# Altium schematic sheet Sheet1.SchDoc
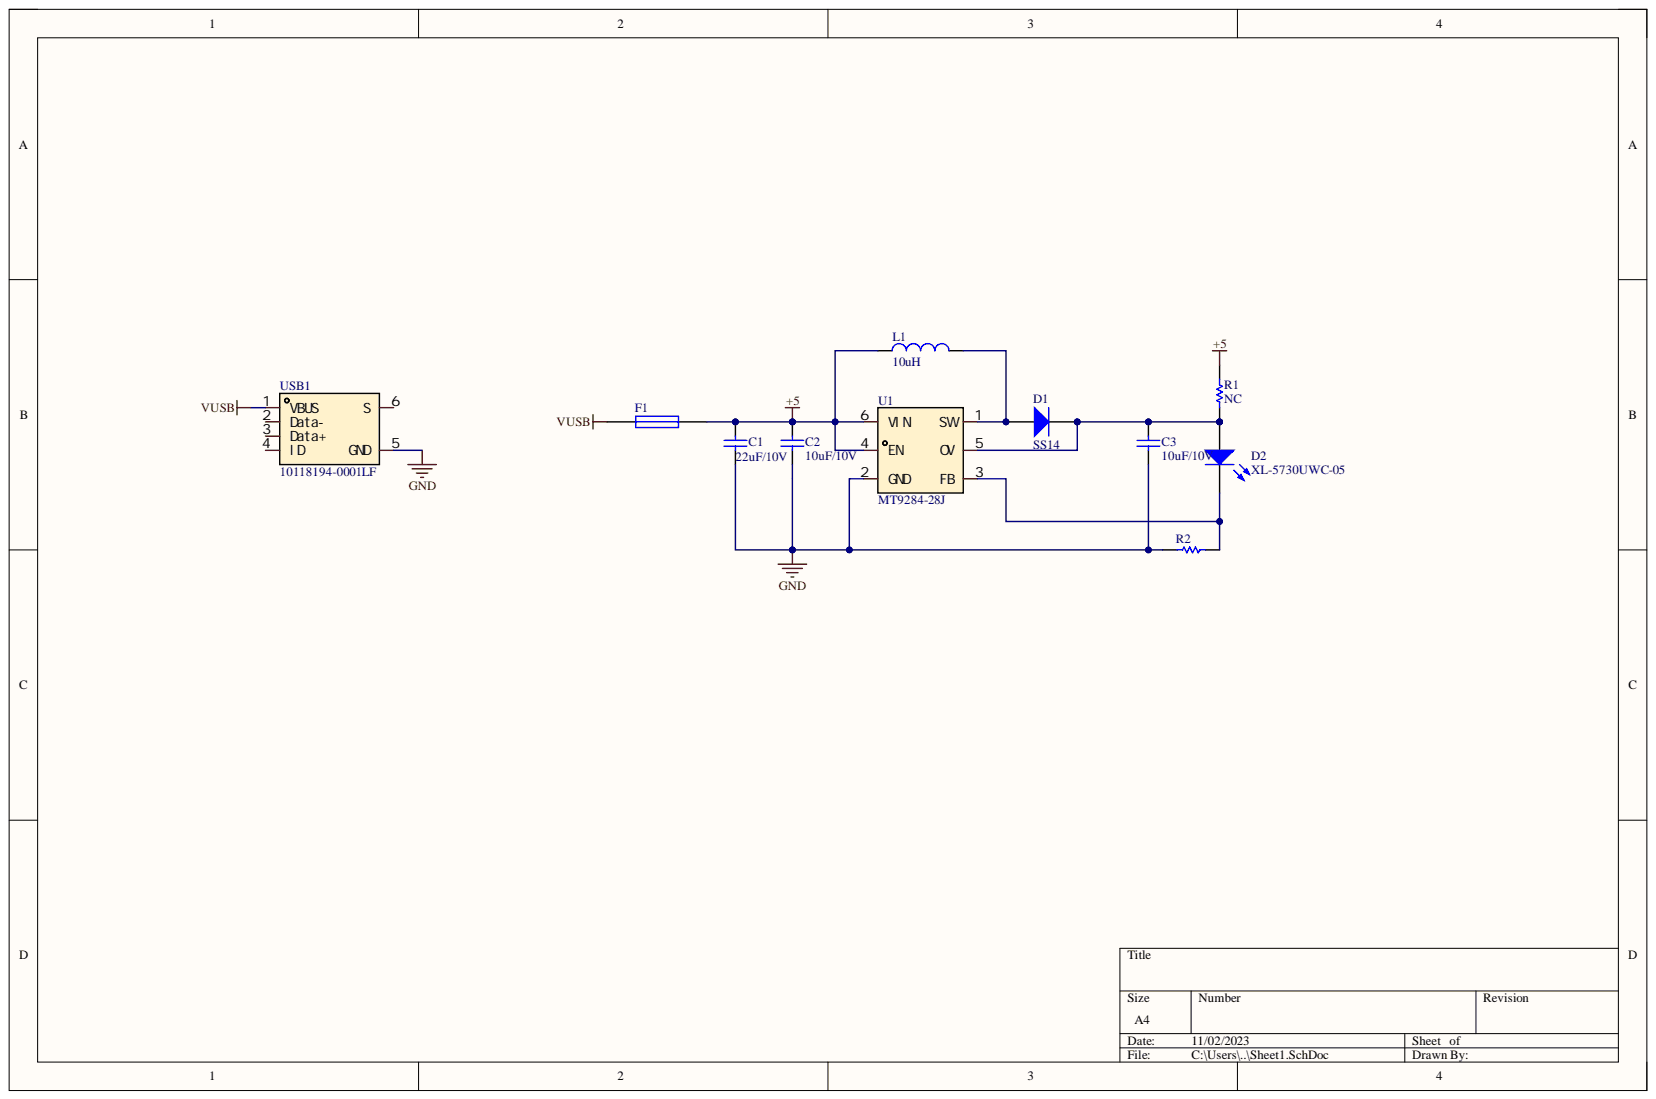
[diagram p0: Sheet1.SchDoc]

## Parts
C1  22uF/10V  [0805-C]
C2  10uF/10V  [0805-C]
C3  10uF/10V  [0805-C]
D1  SS14  [SMA(DO-214AC)]
D2  XL-5730UWC-05  [LED-SMD_3P-L5.7-W3.0-RD]
F1  BSMD0805-050-24V  [0805-L]  (value not drawn)
L1  value 10uH  [IND-SMD_L4.0-W4.0]  (hidden comment: SWPA4030S100MT)
R1  NC  [0805-L]
R2  Res1  [0805-L]  (value not drawn)
U1  MT9284-28J  [SOT-23-6]
USB1  10118194-0001LF  [MICRO-USB-SMD]

## Nets
+5: C1.1 C2.2 F1.1 L1.1 R1.1 U1.4(EN) U1.6(VIN)
GND: C1.2 C2.1 C3.1 R2.1 U1.2(GND) USB1.5(GND)
VUSB: F1.2 USB1.1(VBUS)
NetC3_2: C3.2 D1.2 D2.1 R1.2 U1.5(OV)
NetD1_1: D1.1 L1.2 U1.1(SW)
NetD2_2: D2.2 R2.2 U1.3(FB)

Not connected: USB1 2(Data-) 3(Data+) 4(ID) 6(S)

## Library data of the parts
C1 = Cap: 贴片电容; Component Kind: Standard; LatestRevisionDate: 17-Jul-2002; LatestRevisionNote: Re-released for DXP Platform.; Library Name: Miscellaneous Devices.IntLib; PackageDescription: Radial Cap, Thru-Hole; 2 Leads; 0.3 in Pin Spacing; PackageReference: RAD-0.3; Published: 8-Jun-2000; Signal Integrity: Cap; Simulation: CAP; Publisher: Altium Limited
C2 C3 = Cap: Capacitor; Value: 100pF; Component Kind: Standard; LatestRevisionDate: 17-Jul-2002; LatestRevisionNote: Re-released for DXP Platform.; Library Name: Miscellaneous Devices.IntLib; PackageDescription: Radial Cap, Thru-Hole; 2 Leads; 0.3 in Pin Spacing; PackageReference: RAD-0.3; Published: 8-Jun-2000; Signal Integrity: Cap; Simulation: CAP; Publisher: Altium Limited
D1 = Diode: Default Diode; Code_JEDEC: DO-214-AB; Component Kind: Standard; LatestRevisionDate: 17-Jul-2002; LatestRevisionNote: Re-released for DXP Platform.; Library Name: Miscellaneous Devices.IntLib; PackageDescription: DO-214-AB/SMC; 2 C-Bend Leads; Body 7.9 x 5.9 mm, inc. leads (LxW); PackageReference: SMC; PackageVersion: Sep-1996; Published: 8-Jun-2000; Signal Integrity: Diode; Simulation: DIODE; Publisher: Altium Limited
D2 = LED1: LED-绿; Component Kind: Standard; LatestRevisionDate: 08-Jul-2005; LatestRevisionNote: Mech Layer 1 removed.; Library Name: Schlib1.SchLib; PackageDescription: LED; 2 Leads; PackageReference: LED-1; Published: 8-Jun-2000; Simulation: LED1; Publisher: Altium Limited
F1 = Fuse 1: Component Kind: Standard; LatestRevisionDate: 17-Jul-2002; LatestRevisionNote: Re-released for DXP Platform.; Library Name: Miscellaneous Devices.IntLib; PackageDescription: Thru-Hole; 2 Leads; PackageReference: PIN-W2/E2.8; Published: 8-Jun-2000; Simulation: FUSE; Publisher: Altium Limited
L1 = Inductor: Code_IEC: 1005; Code_JEITA: 0402; Component Kind: Standard; LatestRevisionDate: 29-May-2009; LatestRevisionNote: IPC-7351 Footprint Added.; Library Name: Miscellaneous Devices.IntLib; PackageDescription: Chip Inductor; PackageReference: 0402-A; Published: 8-Jun-2000; Signal Integrity: Inductor; Simulation: INDUCTOR; Publisher: Altium Limited
R1 R2 = Res1: Resistor; Value: 1K; Component Kind: Standard; LatestRevisionDate: 17-Jul-2002; LatestRevisionNote: Re-released for DXP Platform.; Library Name: Miscellaneous Devices.IntLib; PackageDescription: Axial Device, Thru-Hole; 2 Leads; 0.3 in Pin Spacing; PackageReference: AXIAL-0.3; Published: 8-Jun-2000; Signal Integrity: Res; Simulation: RESISTOR; Publisher: Altium Limited
U1 = MT9284-28J: Component Kind: Standard; Library Name: Schlib1.SchLib
USB1 = 10118194-0001LF: Component Kind: Standard; Library Name: Schlib1.SchLib
